# Altium schematic sheet 32_MCU.SchDoc
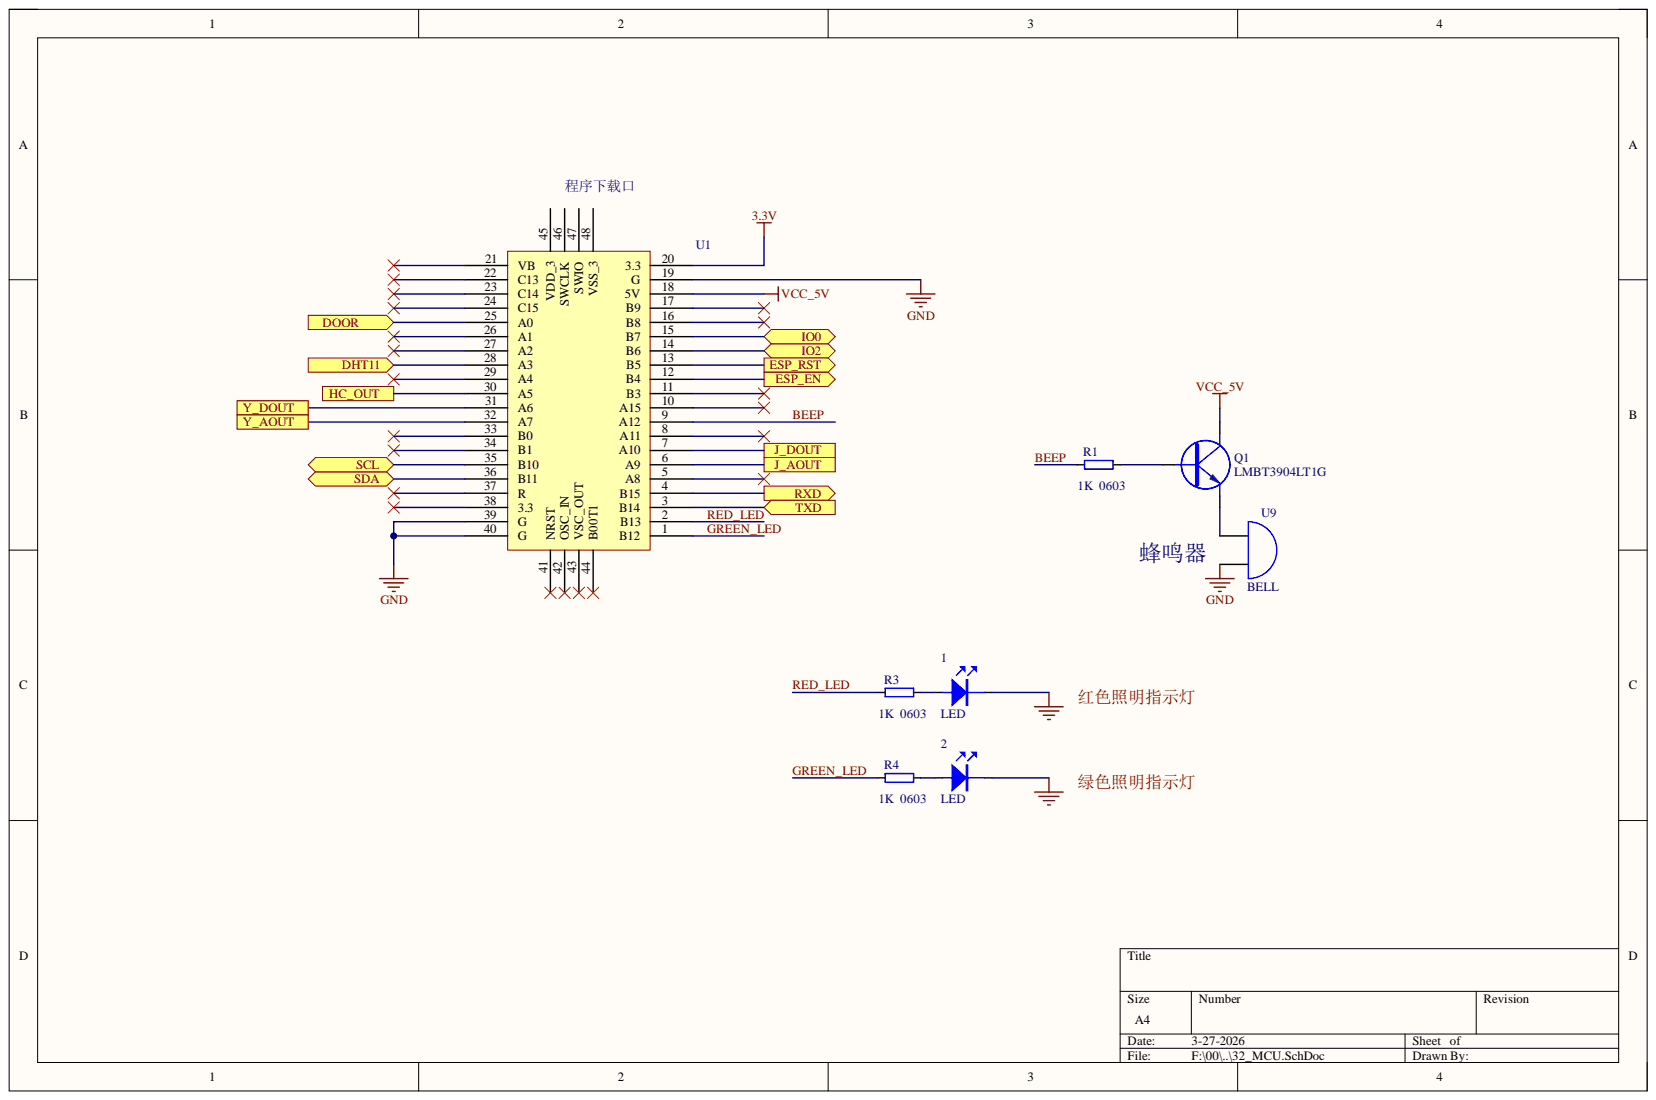
[diagram p0: 32_MCU.SchDoc]

## Parts
1  LED  [LED-TH_BD5.8-P2.54-FD]
2  LED  [LED-TH_BD5.8-P2.54-FD]
Q1  LMBT3904LT1G  [SOT-23-BCE-P]
R1  1K  0603  [C0603]
R3  1K  0603  [C0603]
R4  1K  0603  [C0603]
U1  程序下载口  [STC]
U9  BELL  [BEEP]

## Ports
DHT11, DOOR, ESP_EN, ESP_RST, HC_OUT, IO0, IO2, J_AOUT, J_DOUT, RXD, SCL, SDA, TXD, Y_AOUT, Y_DOUT

## Nets
3.3V: U1.20(3.3)
GND: 1.2 2.2 U1.19(G) U1.39(G) U1.40(G) U9.2
VCC_5V: Q1.C U1.18(5V)
BEEP: R1.2 U1.9(A12)
DHT11: U1.28(A3) ; port DHT11
DOOR: U1.25(A0) ; port DOOR
ESP_EN: U1.12(B4) ; port ESP_EN
ESP_RST: U1.13(B5) ; port ESP_RST
GREEN_LED: R4.2 U1.1(B12)
HC_OUT: U1.30(A5) ; port HC_OUT
IO0: U1.15(B7) ; port IO0
IO2: U1.14(B6) ; port IO2
J_AOUT: U1.6(A9) ; port J_AOUT
J_DOUT: U1.7(A10) ; port J_DOUT
RED_LED: R3.2 U1.2(B13)
RXD: U1.4(B15) ; port RXD
SCL: U1.35(B10) ; port SCL
SDA: U1.36(B11) ; port SDA
TXD: U1.3(B14) ; port TXD
Y_AOUT: U1.32(A7) ; port Y_AOUT
Y_DOUT: U1.31(A6) ; port Y_DOUT
Net1_1: 1.1 R3.1
Net2_1: 2.1 R4.1
NetQ1_B: Q1.B R1.1
NetQ1_E: Q1.E U9.1
红色照明指示灯:
绿色照明指示灯:

Not connected: U1 5(A8) 8(A11) 10(A15) 11(B3) 16(B8) 17(B9) 21(VB) 22(C13) 23(C14) 24(C15) 26(A1) 27(A2) 29(A4) 33(B0) 34(B1) 37(R) 38(3.3) 41(NRST) 42(OSC_IN) 43(VSC_OUT) 44(B00T1) 45(VDD_3) 46(SWCLK) 47(SWIO) 48(VSS_3)

## Library data of the parts
Q1 = LMBT3904LT1G-P-NPN: Q-NPN-#-#-#; Component Kind: Standard; Library Name: S-P半导体器件.SchLib
R1 R3 R4 = 1K: Component Kind: Standard
U1 = STM32F103C8T6-2: Component Kind: Standard
1 2 = LED: Component Kind: Standard
U9 = BELL: Component Kind: Standard; Library Name: 51SCH.SchLib
